# Altium schematic sheet ADC.SchDoc(ADC)
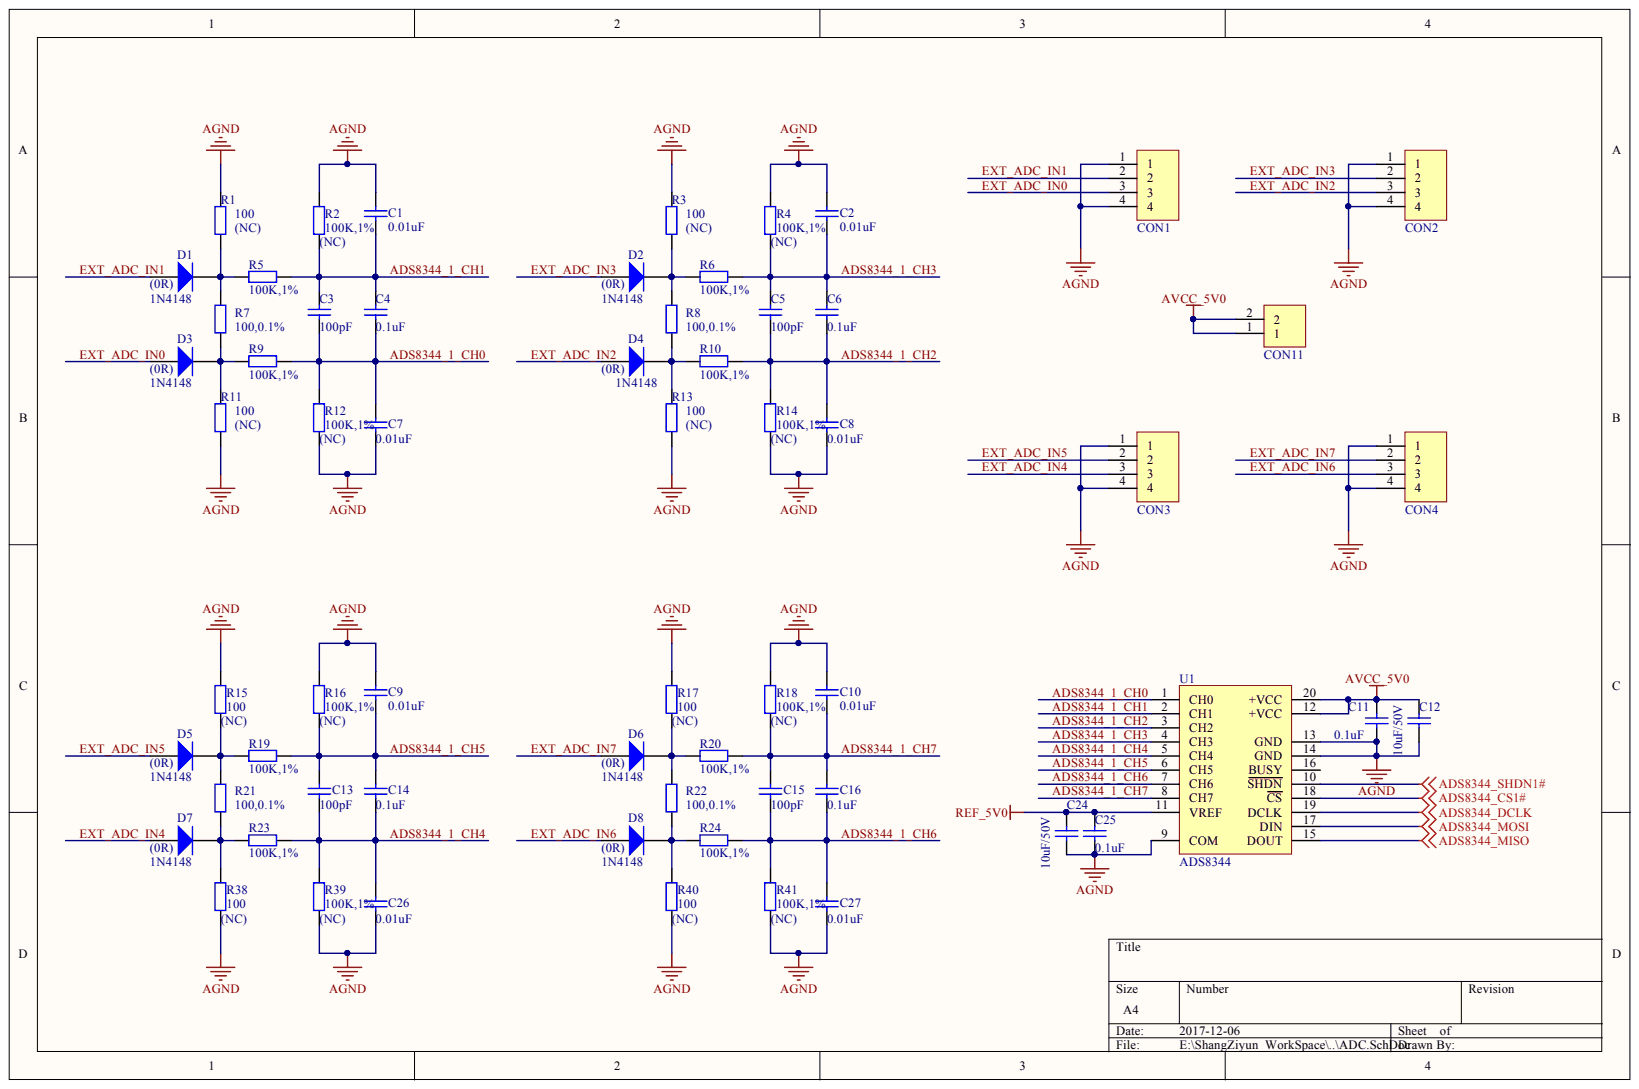
[diagram p0: ADC.SchDoc]

## Parts
C1  C?  value 0.01uF  [0603C]
C2  C?  value 0.01uF  [0603C]
C3  C?  value 100pF  [L0603]
C4  C?  value 0.1uF  [0603C]
C5  C?  value 100pF  [L0603]
C6  C?  value 0.1uF  [0603C]
C7  C?  value 0.01uF  [0603C]
C8  C?  value 0.01uF  [0603C]
C9  C?  value 0.01uF  [0603C]
C10  C?  value 0.01uF  [0603C]
C11  value 0.1uF  [0603C]  (hidden comment: Capacitor)
C12  value 10uF/50V  [0805C]  (hidden comment: Capacitor)
C13  C?  value 100pF  [L0603]
C14  C?  value 0.1uF  [0603C]
C15  C?  value 100pF  [L0603]
C16  C?  value 0.1uF  [0603C]
C24  value 10uF/50V  [0805C]  (hidden comment: Capacitor)
C25  value 0.1uF  [0603C]  (hidden comment: Capacitor)
C26  C?  value 0.01uF  [0603C]
C27  C?  value 0.01uF  [0603C]
CON1  CONNECTOR_4PIN  [HT5.08-4W]  (value not drawn)
CON2  CONNECTOR_4PIN  [HT5.08-4W]  (value not drawn)
CON3  CONNECTOR_4PIN  [HT5.08-4W]  (value not drawn)
CON4  CONNECTOR_4PIN  [HT5.08-4W]  (value not drawn)
CON11  CONNECTOR_2PIN  [HT5.08-2W]  (value not drawn)
D1  D?  value 1N4148  Define: (0R)  [SOD123]
D2  D?  value 1N4148  Define: (0R)  [SOD123]
D3  D?  value 1N4148  Define: (0R)  [SOD123]
D4  D?  value 1N4148  Define: (0R)  [SOD123]
D5  D?  value 1N4148  Define: (0R)  [SOD123]
D6  D?  value 1N4148  Define: (0R)  [SOD123]
D7  D?  value 1N4148  Define: (0R)  [SOD123]
D8  D?  value 1N4148  Define: (0R)  [SOD123]
R1  value 100  Define: (NC)  [1206R]  (hidden comment: Resistor)
R2  value 100K,1%  Define: (NC)  [L0603]  (hidden comment: Resistor)
R3  value 100  Define: (NC)  [1206R]  (hidden comment: Resistor)
R4  value 100K,1%  Define: (NC)  [L0603]  (hidden comment: Resistor)
R5  value 100K,1%  [L0603]  (hidden comment: Resistor)
R6  value 100K,1%  [L0603]  (hidden comment: Resistor)
R7  value 100,0.1%  [1206R]  (hidden comment: Resistor)
R8  value 100,0.1%  [1206R]  (hidden comment: Resistor)
R9  value 100K,1%  [L0603]  (hidden comment: Resistor)
R10  value 100K,1%  [L0603]  (hidden comment: Resistor)
R11  value 100  Define: (NC)  [1206R]  (hidden comment: Resistor)
R12  value 100K,1%  Define: (NC)  [L0603]  (hidden comment: Resistor)
R13  value 100  Define: (NC)  [1206R]  (hidden comment: Resistor)
R14  value 100K,1%  Define: (NC)  [L0603]  (hidden comment: Resistor)
R15  value 100  Define: (NC)  [1206R]  (hidden comment: Resistor)
R16  value 100K,1%  Define: (NC)  [L0603]  (hidden comment: Resistor)
R17  value 100  Define: (NC)  [1206R]  (hidden comment: Resistor)
R18  value 100K,1%  Define: (NC)  [L0603]  (hidden comment: Resistor)
R19  value 100K,1%  [L0603]  (hidden comment: Resistor)
R20  value 100K,1%  [L0603]  (hidden comment: Resistor)
R21  value 100,0.1%  [1206R]  (hidden comment: Resistor)
R22  value 100,0.1%  [1206R]  (hidden comment: Resistor)
R23  value 100K,1%  [L0603]  (hidden comment: Resistor)
R24  value 100K,1%  [L0603]  (hidden comment: Resistor)
R38  value 100  Define: (NC)  [1206R]  (hidden comment: Resistor)
R39  value 100K,1%  Define: (NC)  [L0603]  (hidden comment: Resistor)
R40  value 100  Define: (NC)  [1206R]  (hidden comment: Resistor)
R41  value 100K,1%  Define: (NC)  [L0603]  (hidden comment: Resistor)
U1  ADS8344  [TSSOP20]

## Nets
ADS8344_CS1#: U1.18(CS)
ADS8344_DCLK: U1.19(DCLK)
ADS8344_MISO: U1.15(DOUT)
ADS8344_MOSI: U1.17(DIN)
ADS8344_SHDN1#: U1.10(SHDN)
AGND: C1.1 C2.1 C7.1 C8.1 C9.1 C10.1 C11.2 C12.2 C24.2 C25.2 C26.1 C27.1 CON1.1 CON1.4 CON2.1 CON2.4 CON3.1 CON3.4 CON4.1 CON4.4 R1.1 R2.1 R3.1 R4.1 R11.2 R12.2 R13.2 R14.2 R15.1 R16.1 R17.1 R18.1 R38.2 R39.2 R40.2 R41.2 U1.9(COM) U1.13(GND) U1.14(GND)
AVCC_5V0: C11.1 C12.1 CON11.1 CON11.2 U1.12(+VCC) U1.20(+VCC)
REF_5V0: C24.1 C25.1 U1.11(VREF)
ADS8344_1_CH0: C3.1 C4.1 C7.2 R9.2 R12.1 U1.1(CH0)
ADS8344_1_CH1: C1.2 C3.2 C4.2 R2.2 R5.2 U1.2(CH1)
ADS8344_1_CH2: C5.1 C6.1 C8.2 R10.2 R14.1 U1.3(CH2)
ADS8344_1_CH3: C2.2 C5.2 C6.2 R4.2 R6.2 U1.4(CH3)
ADS8344_1_CH4: C13.1 C14.1 C26.2 R23.2 R39.1 U1.5(CH4)
ADS8344_1_CH5: C9.2 C13.2 C14.2 R16.2 R19.2 U1.6(CH5)
ADS8344_1_CH6: C15.1 C16.1 C27.2 R24.2 R41.1 U1.7(CH6)
ADS8344_1_CH7: C10.2 C15.2 C16.2 R18.2 R20.2 U1.8(CH7)
EXT_ADC_IN0: CON1.3 D3.1
EXT_ADC_IN1: CON1.2 D1.1
EXT_ADC_IN2: CON2.3 D4.1
EXT_ADC_IN3: CON2.2 D2.1
EXT_ADC_IN4: CON3.3 D7.1
EXT_ADC_IN5: CON3.2 D5.1
EXT_ADC_IN6: CON4.3 D8.1
EXT_ADC_IN7: CON4.2 D6.1
NetD1_2: D1.2 R1.2 R5.1 R7.1
NetD2_2: D2.2 R3.2 R6.1 R8.1
NetD3_2: D3.2 R7.2 R9.1 R11.1
NetD4_2: D4.2 R8.2 R10.1 R13.1
NetD5_2: D5.2 R15.2 R19.1 R21.1
NetD6_2: D6.2 R17.2 R20.1 R22.1
NetD7_2: D7.2 R21.2 R23.1 R38.1
NetD8_2: D8.2 R22.2 R24.1 R40.1

Not connected: U1 16(BUSY)

## Library data of the parts
C1-C16 C24-C27 = Cap: Component Kind: Standard
  C11 C12 C24 C25: Description: Capacitor; LatestRevisionDate: 17-Jul-2002; LatestRevisionNote: Re-released for DXP Platform.; Library Name: Miscellaneous Devices.IntLib; PackageDescription: Radial Cap, Thru-Hole; 2 Leads; 0.3 in Pin Spacing; PackageReference: RAD-0.3; Published: 8-Jun-2000; Signal Integrity: Cap; Simulation: CAP; Publisher: Altium Limited
  C1-C10 C13-C16 C26 C27: Library Name: LNG.SCHLIB
CON1-CON4 = CONNECTOR_4PIN: Value: HT5.08-4W; Component Kind: Standard; Library Name: MyLib.SCHLIB
CON11 = CONNECTOR_2PIN: Value: HT5.08-2W; Component Kind: Standard; Library Name: MyLib.SCHLIB
D1-D8 = Diode: Component Kind: Standard; Library Name: MyLib.SCHLIB
R1-R24 R38-R41 = Res: Component Kind: Standard; Library Name: Lib1.SCHLIB
U1 = ADS8344: Component Kind: Standard; Library Name: MyLib.SCHLIB; Value: ADS8344
